# Altium schematic sheet MCU_POWER.SchDoc(MCU_POWER)
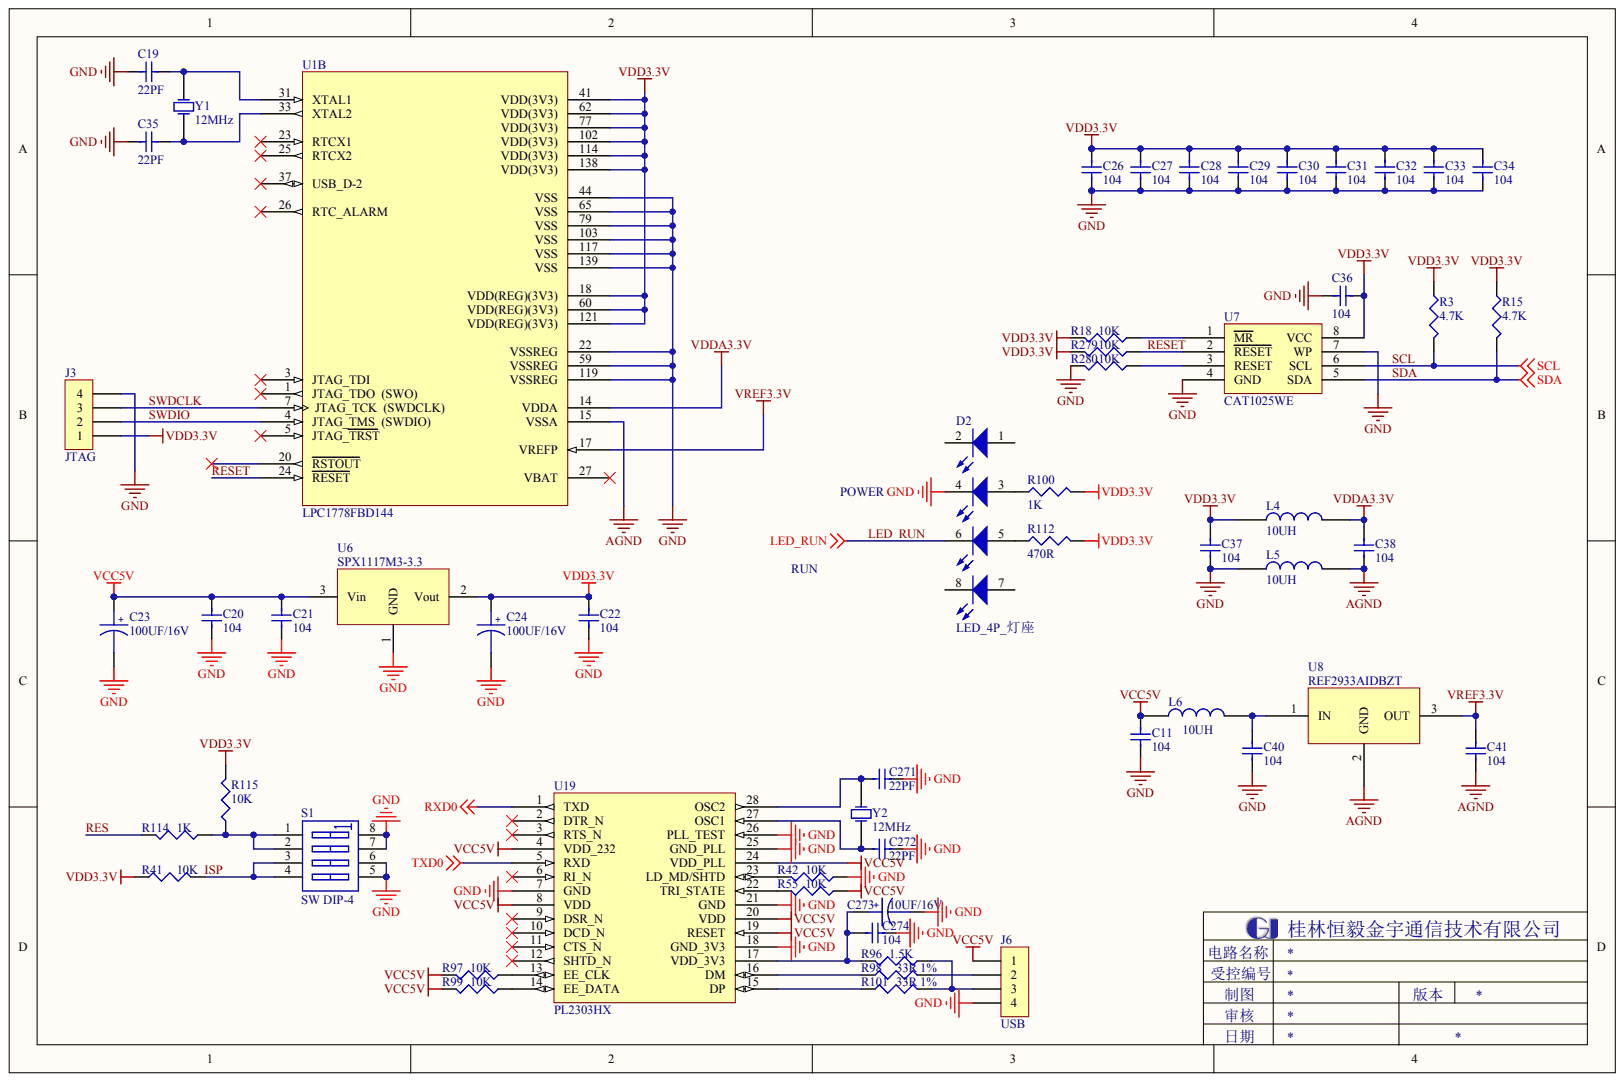
[diagram p0: MCU_POWER.SchDoc]

## Parts
C11  104
C19  22PF
C20  104
C21  104
C22  104
C23  100UF/16V
C24  100UF/16V
C26  104
C27  104
C28  104
C29  104
C30  104
C31  104
C32  104
C33  104
C34  104
C35  22PF
C36  104
C37  104
C38  104
C40  104
C41  104
C271  22PF
C272  22PF
C273  10UF/16V
C274  104
D2  LED_4P_灯座
J3  JTAG
J6  USB
L4  10UH
L5  10UH
L6  10UH
R3  4.7K
R15  4.7K
R18  10K
R41  10K
R42  10K
R55  10K
R96  1.5K
R97  10K
R98  33R 1%
R99  10K
R100  1K
R101  33R 1%
R112  470R
R114  1K
R115  10K
R279  10K
R280  10K
S1  SW DIP-4
U1  LPC1778FBD144  (part B)
U6  SPX1117M3-3.3
U7  CAT1025WE
U8  REF2933AIDBZT
U19  PL2303HX
Y1  12MHz
Y2  12MHz

## Nets
AGND: C38.1 C41.1 L5.2 U1.15(VSSA) U8.2
GND: C11.1 C19.2 C20.1 C21.1 C22.1 C23.2 C24.2 C26.1 C27.1 C28.1 C29.1 C30.1 C31.1 C32.1 C33.1 C34.1 C35.2 C36.2 C37.1 C40.1 C271.2 C272.2 C273.2 C274.1 D2.4 J3.4 J6.4 L5.1 R42.1 R280.2 S1.5 S1.6 S1.7 S1.8 U1.22(VSSREG) U1.44(VSS) U1.59(VSSREG) U1.65(VSS) U1.79(VSS) U1.103(VSS) U1.117(VSS) U1.119(VSSREG) U1.139(VSS) U6.1 U7.4(GND) U7.7(WP) U19.7(GND) U19.18(GND_3V3) U19.21(GND) U19.25(GND_PLL) U19.26(PLL_TEST)
RXD0: U19.1(TXD)
TXD0: U19.5(RXD)
VCC5V: C11.2 C20.2 C21.2 C23.1 J6.1 L6.1 R55.1 R97.2 R99.2 U6.3 U19.4(VDD_232) U19.8(VDD) U19.19(RESET) U19.20(VDD) U19.24(VDD_PLL)
VDD3.3V: C22.2 C24.1 C26.2 C27.2 C28.2 C29.2 C30.2 C31.2 C32.2 C33.2 C34.2 C36.1 C37.2 J3.1 L4.1 R3.1 R15.1 R18.2 R41.1 R100.2 R112.2 R115.2 R279.2 U1.18(VDD(REG)(3V3)) U1.41(VDD(3V3)) U1.60(VDD(REG)(3V3)) U1.62(VDD(3V3)) U1.77(VDD(3V3)) U1.102(VDD(3V3)) U1.114(VDD(3V3)) U1.121(VDD(REG)(3V3)) U1.138(VDD(3V3)) U6.2 U7.8(VCC)
VDDA3.3V: C38.2 L4.2 U1.14(VDDA)
VREF3.3V: C41.2 U1.17(VREFP) U8.3
ISP: R41.2 S1.3 S1.4
LED_RUN: D2.6
RES: R114.2
RESET: R279.1 U1.24(RESET) U7.2(RESET)
SCL: R3.2 U7.6(SCL)
SDA: R15.2 U7.5(SDA)
SWDCLK: J3.3 U1.7(JTAG_TCK)
SWDIO: J3.2 U1.4(JTAG_TMS)
NetC19_1: C19.1 U1.31(XTAL1) Y1.2
NetC35_1: C35.1 U1.33(XTAL2) Y1.1
NetC40_2: C40.2 L6.2 U8.1
NetC271_1: C271.1 U19.28(OSC2) Y2.2
NetC272_1: C272.1 U19.27(OSC1) Y2.1
NetC273_1: C273.1 C274.2 R96.2 U19.17(VDD_3V3)
NetD2_3: D2.3 R100.1
NetD2_5: D2.5 R112.1
NetJ6_2: J6.2 R98.2
NetJ6_3: J6.3 R96.1 R101.2
NetR18_1: R18.1 U7.1(MR)
NetR42_2: R42.2 U19.23(LD_MD/SHTD)
NetR55_2: R55.2 U19.22(TRI_STATE)
NetR97_1: R97.1 U19.13(EE_CLK)
NetR98_1: R98.1 U19.16(DM)
NetR99_1: R99.1 U19.14(EE_DATA)
NetR101_1: R101.1 U19.15(DP)
NetR114_1: R114.1 R115.1 S1.1 S1.2
NetR280_1: R280.1 U7.3(RESET)

Not connected: D2 1 2 7 8; U1 1(JTAG_TDO) 3(JTAG_TDI) 5(JTAG_TRST) 20(RSTOUT) 23(RTCX1) 25(RTCX2) 26(RTC_ALARM) 27(VBAT) 37(USB_D-2); U19 2(DTR_N) 3(RTS_N) 6(RI_N) 9(DSR_N) 10(DCD_N) 11(CTS_N) 12(SHTD_N)
